# Altium schematic sheet Freq-Tim-Sheet1.SchDoc
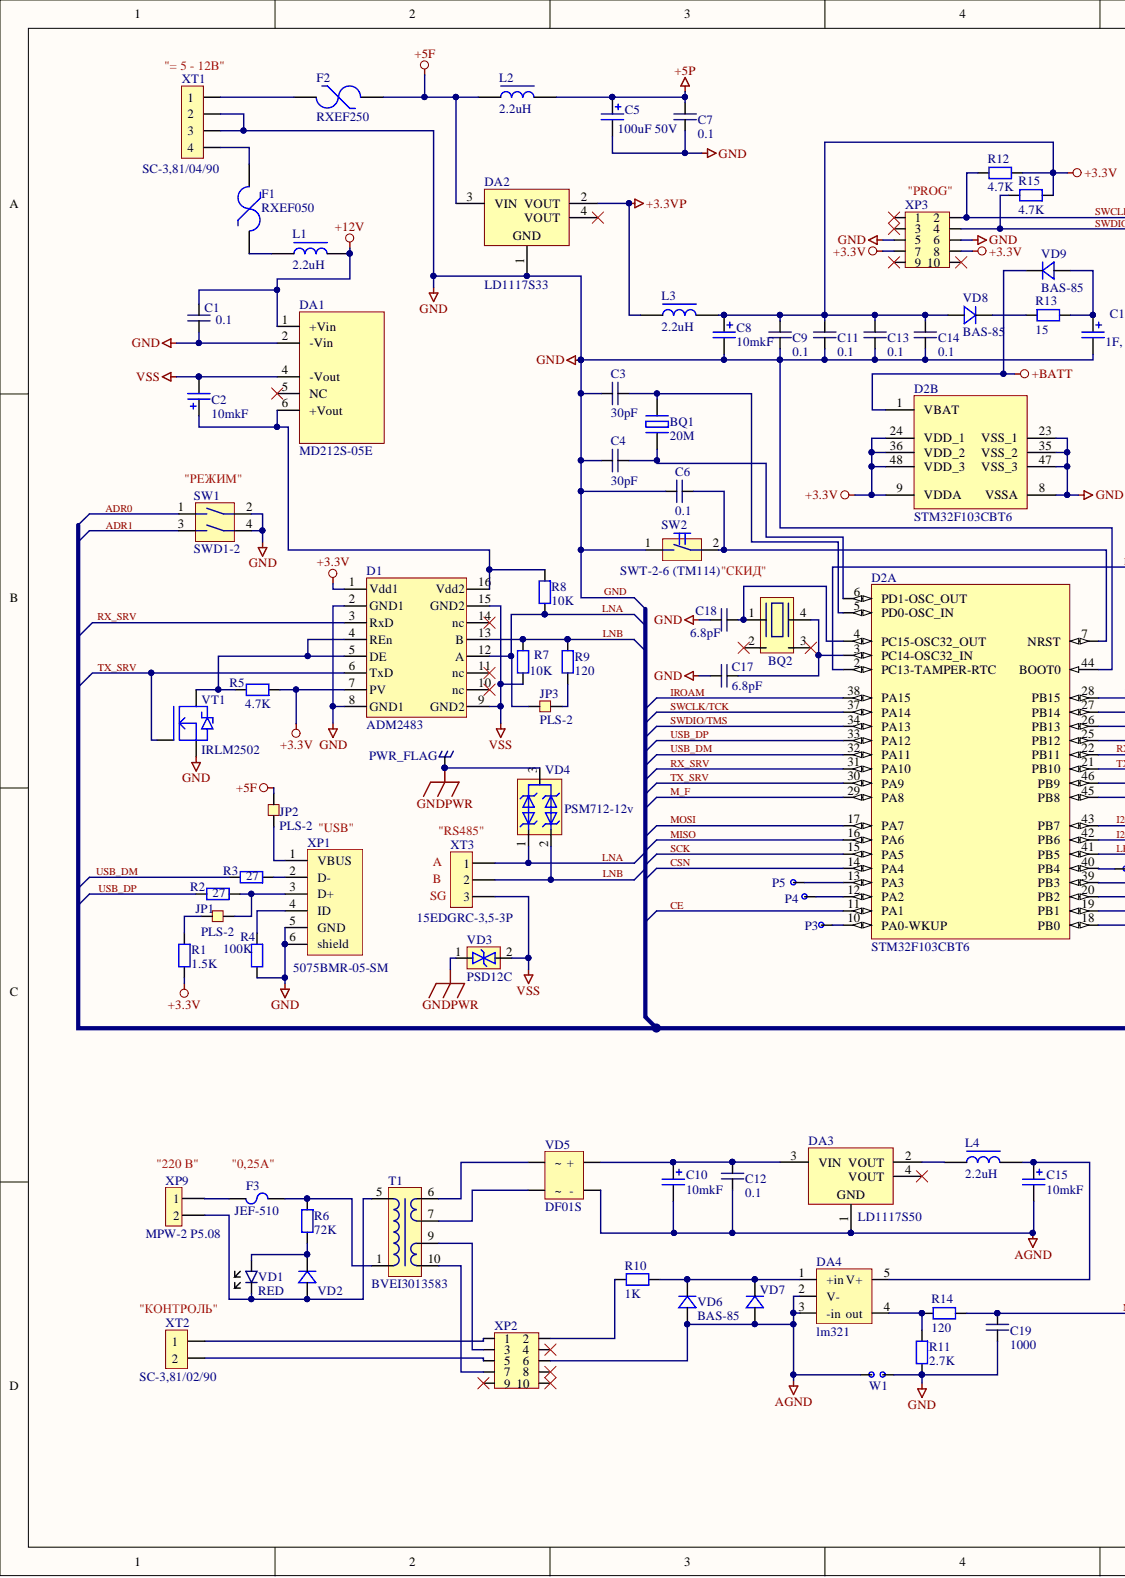
[diagram p0: Freq-Tim-Sheet1.SchDoc, left]
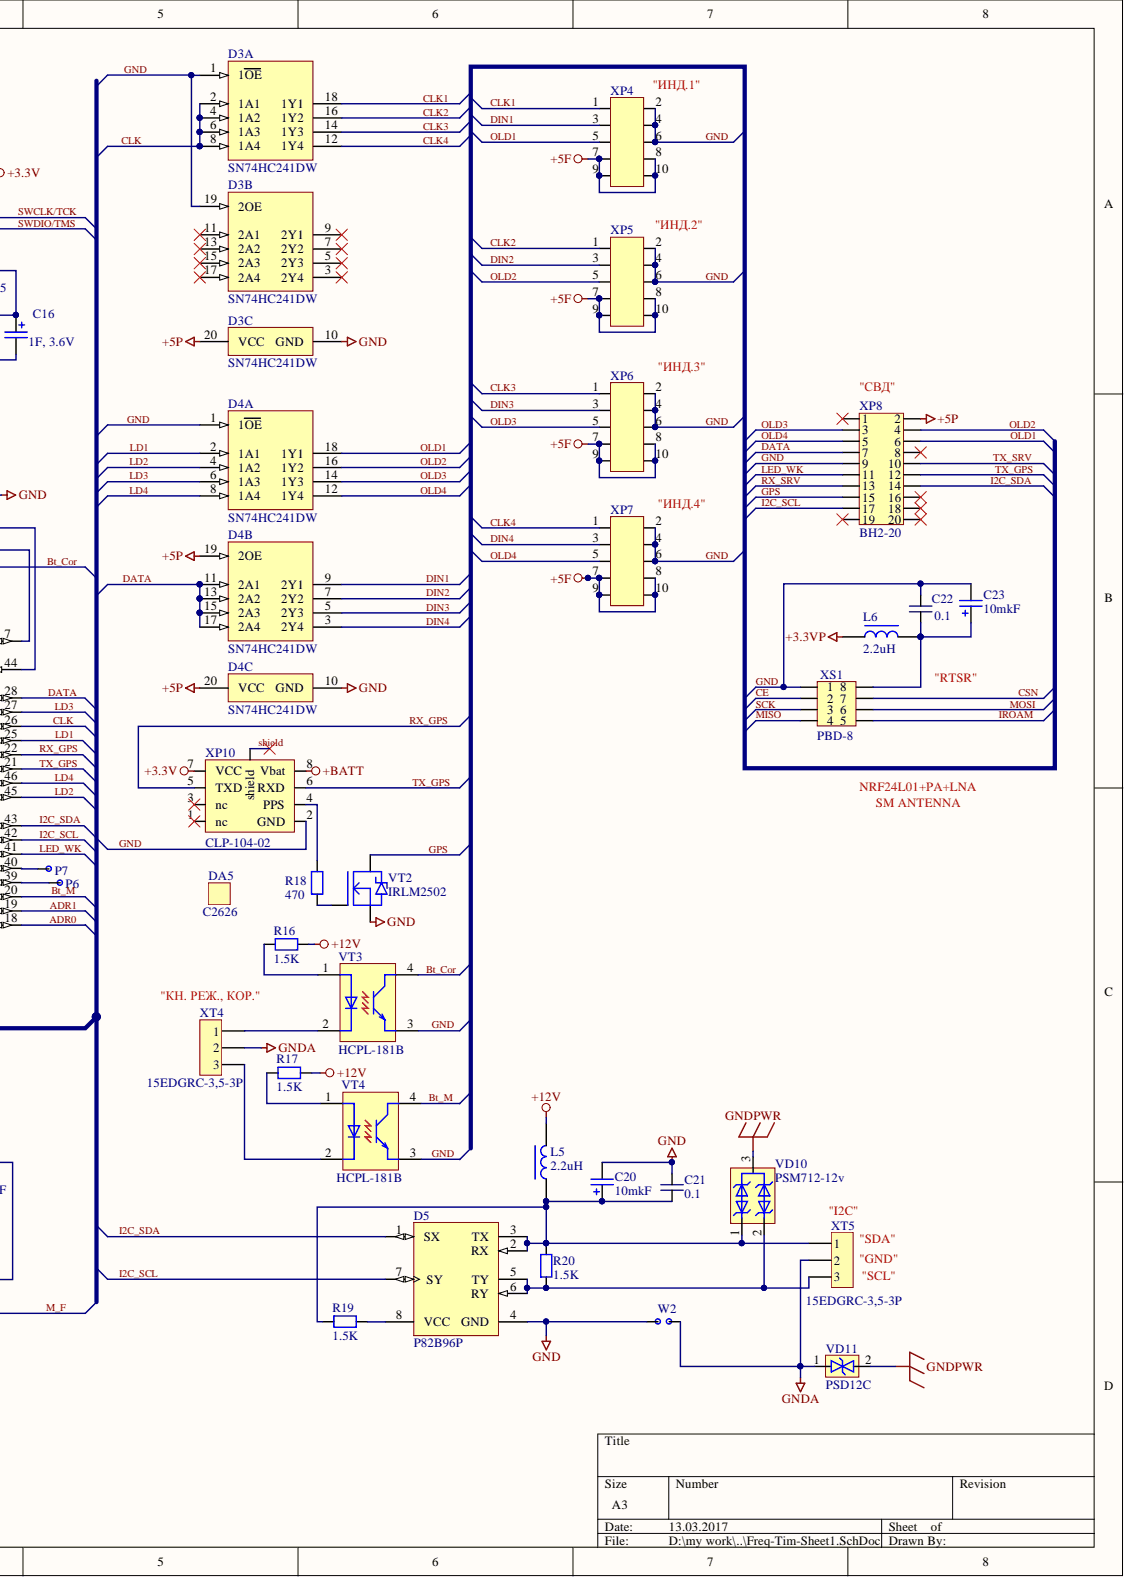
[diagram p1: Freq-Tim-Sheet1.SchDoc, right]

## Parts
BQ1  20M  [HC49US_th]
BQ2  32.768k  [MC306]  (value not drawn)
C1  0.1  [C1206]
C2  10mkF  [AL_CAP_6.5x5.5]
C3  30pF  [C1206]
C4  30pF  [C1206]
C5  100uF 50V  [AL_CAP_8x10]
C6  0.1  [C1206]
C7  0.1  [C1206]
C8  10mkF  [AL_CAP_6.5x5.5]
C9  0.1  [C1206]
C10  10mkF  [AL_CAP_6.5x5.5]
C11  0.1  [C1206]
C12  0.1  [C1206]
C13  0.1  [C1206]
C14  0.1  [C1206]
C15  10mkF  [AL_CAP_6.5x5.5]
C16  1F, 3.6V  [EEC-RG0V105HN]
C17  6.8pF  [C1206]
C18  6.8pF  [C1206]
C19  1000  [C1206]
C20  10mkF  [AL_CAP_6.5x5.5]
C21  0.1  [C1206]
C22  0.1  [C1206]
C23  10mkF  [AL_CAP_6.5x5.5]
D1  ADM2483  [SO16w]
D2  STM32F103CBT6  [STM-LQFP48_N]  (parts A,B)
D3  SN74HC241DW  [DW0020A_N]  (parts A,B,C)
D4  SN74HC241DW  [DW0020A_N]  (parts A,B,C)
D5  P82B96P  [P8]
DA1  MD212S-05E  [am2d-2405s]
DA2  LD1117S33  [SOT-223_N]
DA3  LD1117S50  [SOT-223_N]
DA4  lm321  [sot23_5]
DA5  C2626
F1  RXEF050  [RXEF]
F2  RXEF250  [RXEF250]
F3  JEF-510  [JEF-510]
JP1  PLS-2_  value PLS-2  [PLS-2]
JP2  PLS-2_  value PLS-2  [PLS-2]
JP3  PLS-2_  value PLS-2  [PLS-2]
L1  2.2uH  [P0770]
L2  2.2uH  [P0770]
L3  2.2uH  [P0770]
L4  2.2uH  [P0770]
L5  2.2uH  [P0770]
L6  2.2uH  [P0770]
P3  PIN_1  [PIN_1]  (value not drawn)
P4  PIN_1  [PIN_1]  (value not drawn)
P5  PIN_1  [PIN_1]  (value not drawn)
P6  PIN_1  [PIN_1]  (value not drawn)
P7  PIN_1  [PIN_1]  (value not drawn)
PWR_FLAG  Crimp tab (клемма ножевая угловая)  [earth_terminal_R]  (value not drawn)
R1  1.5K  [RESC3216N]
R2  27  [RESC3216N]
R3  27  [RESC3216N]
R4  100K  [RESC3216N]
R5  4.7K  [RESC3216N]
R6  72K  [RESC3216N]
R7  10K  [RESC3216N]
R8  10K  [RESC3216N]
R9  120  [RESC3216N]
R10  1K  [RESC3216N]
R11  2.7K  [RESC3216N]
R12  4.7K  [RESC3216N]
R13  15  [RESC3216N]
R14  120  [RESC3216N]
R15  4.7K  [RESC3216N]
R16  1.5K  [RESC3216N]
R17  1.5K  [RESC3216N]
R18  470  [RESC3216N]
R19  1.5K  [RESC3216N]
R20  1.5K  [RESC3216N]
SW1  SWD1-2  [SWD1-2]
SW2  SWT-2-6 (TM114)  [TM114]
T1  BVEI3013583  [BVEI301]
VD1  RED  [LED_TH_2.54_r]
VD2  S1A  [DO-214AC]  (value not drawn)
VD3  PSD12C  [SOD-323]
VD4  PSM712-12v  [SOT23N]
VD5  DF01S  [DF10S]
VD6  BAS-85  [SOD80]
VD7  S1A  [DO-214AC]  (value not drawn)
VD8  BAS-85  [SOD80]
VD9  BAS-85  [SOD80]
VD10  PSM712-12v  [SOT23N]
VD11  PSD12C  [SOD-323]
VT1  IRLM2502  [SOT23]
VT2  IRLM2502  [SOT23]
VT3  HCPL-181B  [HCPL-181]
VT4  HCPL-181B  [HCPL-181]
W1  PIN  [PIN]  (value not drawn)
W2  PIN  [PIN]  (value not drawn)
XP1  5075BMR-05-SM  [5075BMR-05-SM]
XP2  BH10  [BH10]  (value not drawn)
XP3  BH10  [BH10]  (value not drawn)
XP4  IDC10R  [BH-10R]  (value not drawn)
XP5  IDC10R  [BH-10R]  (value not drawn)
XP6  IDC10R  [BH-10R]  (value not drawn)
XP7  IDC10R  [BH-10R]  (value not drawn)
XP8  BH2-20  [BH2-20]
XP9  MPW-2 P5.08  [MPW-2]
XP10  CLP-104-02  [C2626_MODULE]
XS1  PBD-8  [PBD-8+NRF24L01-PA]
XT1  SC-3,81/04/90  [SC_3_81_90G_04]
XT2  SC-3,81/02/90  [SC_3_81_90G_02]
XT3  15EDGRC-3,5-3P  [15EDGRC-3,5-3P]
XT4  15EDGRC-3,5-3P  [15EDGRC-3,5-3P]
XT5  15EDGRC-3,5-3P  [15EDGRC-3,5-3P]

## Nets
+3.3V: C8.1 C9.2 C11.2 C13.2 C14.2 D1.1(Vdd1) D1.7(PV) D2.9(VDDA) D2.24(VDD_1) D2.36(VDD_2) D2.48(VDD_3) L3.2 R1.1 R5.2 R12.2 R15.2 VD8.A XP3.7 XP3.8 XP10.7(VCC)
+3.3VP: DA2.2(VOUT) L3.1 L6.1
+5F: DA2.3(VIN) F2.2 JP2.2 L2.1 XP4.7 XP4.8 XP4.9 XP4.10 XP5.7 XP5.8 XP5.9 XP5.10 XP6.7 XP6.8 XP6.9 XP6.10 XP7.7 XP7.8 XP7.9 XP7.10
+5P: C5.1 C7.2 D3.20(VCC) D4.19(2OE) D4.20(VCC) L2.2 XP8.2
+12V: C1.2 DA1.1(+Vin) L1.2 L5.2 R16.1 R17.1
+BATT: D2.1(VBAT) VD9.C XP10.8(Vbat)
AGND: C10.2 C12.1 C15.2 DA3.1 DA4.2(V-) DA4.3(-in) VD5.2(-) VD6.A VD7.2 W1.1 XP2.6
GNDA: VD11.1 W2.2 XT4.2 XT5.2
GNDPWR: PWR_FLAG.1 VD3.1 VD4.3 VD10.3 VD11.2
VSS: C2.2 D1.9(GND2) D1.15(GND2) DA1.4(-Vout) R7.1 VD3.2 XT3.3
ADR0: D2.18(PB0) SW1.1
ADR1: D2.19(PB1) SW1.3
BT_COR: D2.2(PC13-TAMPER-RTC) VT3.4
BT_M: D2.20(PB2) VT4.4
CE: D2.11(PA1) XS1.2
CLK: D2.26(PB13) D3.2(1A1) D3.4(1A2) D3.6(1A3) D3.8(1A4)
CLK1: D3.18(1Y1) XP4.1
CLK2: D3.16(1Y2) XP5.1
CLK3: D3.14(1Y3) XP6.1
CLK4: D3.12(1Y4) XP7.1
CSN: D2.14(PA4) XS1.7
DATA: D2.28(PB15) D4.11(2A1) D4.13(2A2) D4.15(2A3) D4.17(2A4) XP8.7
DIN1: D4.9(2Y1) XP4.3
DIN2: D4.7(2Y2) XP5.3
DIN3: D4.5(2Y3) XP6.3
DIN4: D4.3(2Y4) XP7.3
GND: C1.1 C3.1 C4.1 C5.2 C6.1 C7.1 C8.2 C9.1 C11.1 C13.1 C14.1 C16.2 C17.1 C18.1 C19.1 C20.2 C21.2 C22.2 C23.2 D1.2(GND1) D1.8(GND1) D2.8(VSSA) D2.23(VSS_1) D2.35(VSS_2) D2.44(BOOT0) D2.47(VSS_3) D3.1(1OE) D3.10(GND) D3.19(2OE) D4.1(1OE) D4.10(GND) D5.4(GND) DA1.2(-Vin) DA2.1 R4.1 R11.1 SW1.2 SW1.4 SW2.1 VT1.2 VT2.2 VT3.3 VT4.3 W1.2 W2.1 XP1.5(GND) XP1.6(shield) XP3.5 XP3.6 XP4.2 XP4.4 XP4.6 XP5.2 XP5.4 XP5.6 XP6.2 XP6.4 XP6.6 XP7.2 XP7.4 XP7.6 XP8.9 XP10.2(GND) XS1.1 XT1.2 XT1.3
GPS: VT2.3 XP8.15
I2C_SCL: D2.42(PB6) D5.7(SY) XP8.17
I2C_SDA: D2.43(PB7) D5.1(SX) XP8.14
IROAM: D2.38(PA15) XS1.5
LD1: D2.25(PB12) D4.2(1A1)
LD2: D2.45(PB8) D4.4(1A2)
LD3: D2.27(PB14) D4.6(1A3)
LD4: D2.46(PB9) D4.8(1A4)
LED_WK: D2.41(PB5) XP8.11
LNA: D1.12(A) JP3.1 R8.1 VD4.1 XT3.1
LNB: D1.13(B) R7.2 R9.2 VD4.2 XT3.2
M_F: C19.2 D2.29(PA8) R14.1
MISO: D2.16(PA6) XS1.4
MOSI: D2.17(PA7) XS1.6
OLD1: D4.18(1Y1) XP4.5 XP8.6
OLD2: D4.16(1Y2) XP5.5 XP8.4
OLD3: D4.14(1Y3) XP6.5 XP8.3
OLD4: D4.12(1Y4) XP7.5 XP8.5
RX_GPS: D2.22(PB11) XP10.5(TXD)
RX_SRV: D1.3(RxD) D2.31(PA10) XP8.13
SCK: D2.15(PA5) XS1.3
SHIELD: XP10.9(shield)
SWCLK/TCK: D2.37(PA14) R12.1 XP3.2
SWDIO/TMS: D2.34(PA13) R15.1 XP3.4
TX_GPS: D2.21(PB10) XP8.12 XP10.6(RXD)
TX_SRV: D1.6(TxD) D2.30(PA9) VT1.1 XP8.10
USB_DM: D2.32(PA11) R3.1
USB_DP: D2.33(PA12) R2.1
NetBQ1_1: BQ1.1 C4.2 D2.6(PD1-OSC_OUT)
NetBQ1_2: BQ1.2 C3.2 D2.5(PD0-OSC_IN)
NetBQ2_1: BQ2.1 C18.2 D2.4(PC15-OSC32_OUT)
NetBQ2_4: BQ2.4 C17.2 D2.3(PC14-OSC32_IN)
NetC2_1: C2.1 D1.16(Vdd2) DA1.6(+Vout) R8.2
NetC6_2: C6.2 D2.7(NRST) SW2.2
NetC10_1: C10.1 C12.2 DA3.3(VIN) VD5.1(+)
NetC15_1: C15.1 DA4.5(V+) L4.2
NetC16_1: C16.1 R13.1 VD9.A
NetC20_1: C20.1 C21.1 D5.2(RX) D5.3(TX) L5.1 R19.2 R20.1 VD10.1 XT5.1
NetC22_1: C22.1 C23.1 L6.2 XS1.8
NetD1_4: D1.4(REn) D1.5(DE) R5.1 VT1.3
NetD2_10: D2.10(PA0-WKUP) P3.1
NetD2_12: D2.12(PA2) P4.1
NetD2_13: D2.13(PA3) P5.1
NetD2_39: D2.39(PB3) P6.1
NetD2_40: D2.40(PB4) P7.1
NetD5_5: D5.5(TY) D5.6(RY) R20.2 VD10.2 XT5.3
NetD5_8: D5.8(VCC) R19.1
NetDA3_2: DA3.2(VOUT) L4.1
NetDA4_1: DA4.1(+in) R10.2 VD6.C VD7.1
NetDA4_4: DA4.4(out) R11.2 R14.2
NetF1_1: F1.1 L1.1
NetF1_2: F1.2 XT1.4
NetF2_1: F2.1 XT1.1
NetF3_1: F3.1 XP9.1
NetF3_2: F3.2 R6.2 T1.1
NetJP1_1: JP1.1 R1.2
NetJP1_2: JP1.2 R2.2 XP1.3(D+)
NetJP2_1: JP2.1 XP1.1(VBUS)
NetJP3_2: JP3.2 R9.1
NetR3_2: R3.2 XP1.2(D-)
NetR4_2: R4.2 XP1.4(ID)
NetR6_1: R6.1 VD1.1 VD2.1
NetR10_1: R10.1 XP2.2
NetR13_2: R13.2 VD8.C
NetR16_2: R16.2 VT3.1
NetR17_2: R17.2 VT4.1
NetR18_1: R18.1 XP10.4(PPS)
NetR18_2: R18.2 VT2.1
NetT1_5: T1.5 VD1.2 VD2.2 XP9.2
NetT1_6: T1.6 VD5.4(~)
NetT1_7: T1.7 VD5.3(~)
NetT1_9: T1.9 XP2.3
NetT1_10: T1.10 XP2.7
NetVT3_2: VT3.2 XT4.1
NetVT4_2: VT4.2 XT4.3
NetXP2_1: XP2.1 XT2.1
NetXP2_5: XP2.5 XT2.2
N00041:
N00042:

Not connected: BQ2 2 3; D1 10(nc) 11(nc) 14(nc); D3 3(2Y4) 5(2Y3) 7(2Y2) 9(2Y1) 11(2A1) 13(2A2) 15(2A3) 17(2A4); DA1 5(NC); DA2 4(VOUT); DA3 4(VOUT); XP2 4 8 9 10; XP3 1 3 9 10; XP8 1 8 16 18 19 20; XP10 1(nc) 3(nc)

## Library data of the parts
BQ1 = HC49US: Value: HC49US-20 MHz; Component Kind: Standard; Library Name: _Energosv.SchLib
BQ2 = MC306-G-06Q: Value: MC306-G-06Q-32.768; Component Kind: Standard; Library Name: _Energosv.SchLib
C1 C6 C7 C9 C11-C14 C21 C22 = C1206: Value: 1206 X7R 25V 100nF В± 5%; Component Kind: Standard; ValueShort: 0.1; Library Name: _Energosv.SchLib
C2 C8 C10 C15 C20 C23 = AL_CAP_6.5x5.5: Component Kind: Standard; Value: 10mkF; Library Name: _Energosv.SchLib
C3 C4 = C1206: Value: 1206 X7R 25V 30 pF ± 5%; Component Kind: Standard; ValueShort: 30pF; Library Name: _Energosv.SchLib
C5 = AL_CAP_8x10: Component Kind: Standard; Value: 100uF 50V; Library Name: _Energosv.SchLib
C16 = EEC-RG0V105HN: Component Kind: Standard; Value: EEC-RG0V105HN; ValueShort: 1F, 3.6V; Library Name: _Energosv.SchLib
C17 C18 = C1206: Value: 1206 X7R 25V 6,8 pF ± 5%; Component Kind: Standard; ValueShort: 6.8pF; Library Name: _Energosv.SchLib
C19 = C1206: Value: 1206 X7R 25V 1nF± 5%; Component Kind: Standard; ValueShort: 1000; Library Name: _Energosv.SchLib
D1 = ADM2483: Value: ADM2483BRW-SO16w; Component Kind: Standard; Library Name: _Energosv.SchLib
D2 = STM32F103CBT6: ARM Cortex-M3 32-bit MCU, 128 KB Flash, 20 KB Internal RAM, 37 I/Os, 48-pin LQFP, -40 to 85 degC, Tray; A/D Converter: 2x12-bit (10 Channel); FLASH Size (Prog) (kB): 128; I/Os (High Current): 37; Internal RAM Size (kB): 20; Operating Frequency(F) (Processor speed) (MHz): 72; Supply Voltage(Vcc) min (V): 2; Component Kind: Standard; ComponentLink1Description: Manufacturer URL; ComponentLink1URL: http://www.st.com/web/en/home.html; ComponentLink2Description: Datasheet; ComponentLink2URL: http://www.st.com/st-web-ui/static/active/en/resource/technical/document/datasheet/CD00161566.pdf; ComponentLink3Description: Package Specification; ComponentLink3URL: http://www.st.com/st-web-ui/static/active/en/resource/technical/document/datasheet/DM00058181.pdf; Core: ARM Cortex-M3; DatasheetVersion: Rev. 16; Mounting Technology: Surface Mount; PackageDescription: 48-Pin Low Profile Quad Flat Package, 7 x 7 mm Body, 0.5 mm Pitch; PackageReference: LQFP48; PackageVersion: Rev. 6, 06/2013; Packing: Tray; PartNumber: STM32F103CBT6; Supplier 1: Digi-Key; Supplier 2: Farnell; Supplier 3: Newark; Supplier 4: Newark; Supplier 5: Mouser; Supplier Part Number 1: 497-6288-ND; Supplier Part Number 2: 1606327; Supplier Part Number 3: 11N8296; Supplier Part Number 4: 57P1214; Supplier Part Number 5: 511-STM32F103CBT6; Library Name: _Energosv.SchLib
D3 D4 = SN74HC241DW: Octal Buffers And Line Drivers With 3-State Outputs, DW0020A, TUBE; Manufacturer: Texas Instruments; Bits(#): 8; F @ Nom Voltage(Max)(Mhz): 28; ICC @ Nom Voltage(Max)(mA): 0.08; Input Type: CMOS; Rating: Catalog; tpd @ Nom Voltage(Max)(ns): 25; VCC(Max)(V): 6; VCC(Min)(V): 2; Code_JEDEC: MS-013; Component Kind: Standard; ComponentLink1Description: Manufacturer URL; ComponentLink1URL: http://www.ti.com/; ComponentLink2Description: Datasheet; ComponentLink2URL: http://www.ti.com/lit/ds/symlink/sn74hc241.pdf; ComponentLink3Description: Package Specification; ComponentLink3URL: http://www.ti.com/lit/ml/mpds173a/mpds173a.pdf; DatasheetVersion: SCLS300C; Generic Part Number: SN74HC241; Operating Temperature Range(C): -40 to 85; Output Type: CMOS; PackageReference: DW0020A; PartNumber: SN74HC241DW; Voltage(Nom)(V): 6; Library Name: _Energosv.SchLib
D5 = P82B96P: Dual Bidirectional Bus Buffer, 2 Channel Width, 2.0 to 15.0 V, -40 to 85 degC, 8-pin PDIP (P), Pb-Free (RoHS); Manufacturer: Texas Instruments; 5V Tolerant I/O: Yes; Channel Width: 2; Eco-Plan: Green (RoHS & no Sb/Br); Master Side I2C Bus Capacitance Supported(pF): 400; SubFamily: I2C Hub, Buffer, Repeater; VCC(Max)(V): 15; Code_JEDEC: MS-001-BA; Component Kind: Standard; ComponentLink1Description: Datasheet; ComponentLink1URL: http://www.ti.com/general/docs/lit/getliterature.tsp?genericPartNumber=P82B96&fileType=pdf; ComponentLink2Description: Package Specification; ComponentLink2URL: http://www.ti.com/litv/pdf/mpdi001b; DatasheetVersion: SCPS144B; Max Frequency(kHz): 400; Mounting Technology: Through-hole; No. of Outputs: 2; Open Drain I/O Type: Yes; PackageDescription: 8-Pin Plastic Dual-In-Line Package, Row Spacing 7.62 mm, Pitch 2.54 mm; PackageReference: P8; PackageVersion: revE, Apr-2010; PartNumber: P82B96P; Slave Side I2C Bus Capacitance Supported(pF): 400; Supplier 1: Digi-Key; Supplier 2: Farnell; Supplier 3: Newark; Supplier 4: Mouser; Supplier Part Number 1: 296-20943-5-ND; Supplier Part Number 2: 1576673; Supplier Part Number 3: 88K6386; Supplier Part Number 4: 595-P82B96P; VCC(Min)(V): 2; Vcc range(V): 2.0 to 15.0; Library Name: _Energosv.SchLib
DA2 = LD1117S33: Fixed Low Drop Positive Voltage Regulator, 3.3V, 4-Pin SOT-223, Tape and Reel; PartNumber: LD1117S33TR; Component Kind: Standard; ComponentLink1Description: Manufacturer URL; ComponentLink1URL: http://www.st.com; ComponentLink2Description: Datasheet; ComponentLink2URL: http://www.st.com/internet/com/TECHNICAL_RESOURCES/TECHNICAL_LITERATURE/DATASHEET/CD00000544.pdf; DatasheetVersion: Nov-2011; PackageDescription: 4-Pin SOT-223 Package, 6.5 x 3.5 mm Body, 2.3 mm Pitch, 7 mm Lead Span; PackageReference: SOT-223; PackageVersion: 2010; Library Name: _Energosv.SchLib
DA3 = LD1117S50: Fixed Low Drop Positive Voltage Regulator, 5V, 4-Pin SOT-223, Tape and Reel; PartNumber: LD1117S50TR; Component Kind: Standard; ComponentLink1Description: Manufacturer URL; ComponentLink1URL: http://www.st.com; ComponentLink2Description: Datasheet; ComponentLink2URL: http://www.st.com/internet/com/TECHNICAL_RESOURCES/TECHNICAL_LITERATURE/DATASHEET/CD00000544.pdf; DatasheetVersion: Nov-2011; PackageDescription: 4-Pin SOT-223 Package, 6.5 x 3.5 mm Body, 2.3 mm Pitch, 7 mm Lead Span; PackageReference: SOT-223; PackageVersion: 2010; Library Name: _Energosv.SchLib
DA5 = DummyComponent: Component Kind: Standard; Value: C2626; Library Name: _Energosv.SchLib
L1-L6 = P0770.xxx: Value: P0770.222-SMD; Component Kind: Standard; Library Name: _Energosv.SchLib
P3-P7 = PIN_1: Value: PINx; Component Kind: Standard; Library Name: _Energosv.SchLib
PWR_FLAG = EARTH_pin_R: Component Kind: Standard; Value: Crimp tab (клемма ножевая угловая); Library Name: _Energosv.SchLib
R1 R16 R17 R19 R20 = R1206: Value: 1206 RC01 1,5 kOm ± 5%; Component Kind: Standard; ValueShort: 1.5K; Library Name: _Energosv.SchLib
R2 R3 = R1206: Value: 1206 RC01 27 Om ± 5%; Component Kind: Standard; ValueShort: 27; Library Name: _Energosv.SchLib
R4 = R1206: Value: 1206 RC01 100 кОm ± 5%; Component Kind: Standard; ValueShort: 100K; Library Name: _Energosv.SchLib
R5 R12 R15 = R1206: Value: 1206 RC01 4,7 кОm ±5 %; Component Kind: Standard; ValueShort: 4.7K; Library Name: _Energosv.SchLib
R6 = R1206: Value: 1206 RC01 72 kOm ± 5%; Component Kind: Standard; ValueShort: 72K; Library Name: _Energosv.SchLib
R7 R8 = R1206: Value: 1206 RC01 10 кОm ± 5%; Component Kind: Standard; ValueShort: 10K; Library Name: _Energosv.SchLib
R9 R14 = R1206: Value: 1206 RC01 120 Оm ± 5%; Component Kind: Standard; ValueShort: 120; Library Name: _Energosv.SchLib
R10 = R1206: Value: 1206 RC01 1,0 кОm ± 5%; Component Kind: Standard; ValueShort: 1K; Library Name: _Energosv.SchLib
R11 = R1206: Value: 1206 RC01 2,7 kOm ± 5%; Component Kind: Standard; ValueShort: 2.7K; Library Name: _Energosv.SchLib
R13 = R1206: Value: 1206 RC01 15 Om ± 5%; Component Kind: Standard; ValueShort: 15; Library Name: _Energosv.SchLib
R18 = R1206: Value: 1206 RC01 470 Om ± 5%; Component Kind: Standard; ValueShort: 470; Library Name: _Energosv.SchLib
SW2 = SWT-2-6: Component Kind: Standard; Library Name: _Energosv.SchLib
VD1 = LED_th_r: Value: L-934SURC; Component Kind: Standard; Library Name: _Energosv.SchLib
VD3 VD11 = PSD12C: TVS; Component Kind: Standard; Value: PSD12C; Library Name: _Energosv.SchLib
VD4 VD10 = PSM712-12v: TVS array; Component Kind: Standard; Value: PSM712-12v; Library Name: _Energosv.SchLib
VD5 = DF01S: Bridge rectifier; Component Kind: Standard; Value: DF01S; Library Name: _Energosv.SchLib
VT3 VT4 = HCPL-181B: Optocoupler; Component Kind: Standard; Value: HCPL-181B; Library Name: _Energosv.SchLib
W1 W2 = PIN: Value: PINx; Component Kind: Standard; Library Name: _Energosv.SchLib
XP1 = 5075BMR-05-SM: Mini USB male; Component Kind: Standard; Value: 5075BMR-05-SM; Library Name: _Energosv.SchLib
XP4-XP7 = BH-10R: Component Kind: Standard; Value: IDC10R; Library Name: _Energosv.SchLib
XP9 = MPW-2: Component Kind: Standard; Value: MPW-2 P5.08; Library Name: _Energosv.SchLib
XP10 = C2626_CLP-104-02: Component Kind: Standard; Value: CLP-104-02; Library Name: _Energosv.SchLib
XT1 = SC-3,81/04/90G: Component Kind: Standard; Value: SC-3,81/04/90; Library Name: _Energosv.SchLib
XT2 = SC-3,81/02/90G: Component Kind: Standard; Value: SC-3,81/02/90; Library Name: _Energosv.SchLib
DA1 = MD212S-05E: Component Kind: Standard; Value: MD212S-05E; Library Name: _Energosv.SchLib
DA4 = lm321: Component Kind: Standard; Value: lm321; Library Name: _Energosv.SchLib
F1 = RXEF050: Component Kind: Standard; Value: RXEF050; Library Name: _Energosv.SchLib
F2 = RXEF250: Component Kind: Standard; Value: RXEF250; Library Name: _Energosv.SchLib
F3 = JEF-510: Component Kind: Standard; Value: JEF-510; Library Name: _Energosv.SchLib
JP1-JP3 = PLS-2_: Component Kind: Standard; Library Name: _Energosv.SchLib
SW1 = SWD1-2: Component Kind: Standard; Library Name: _Energosv.SchLib
T1 = BVEI3013583: Component Kind: Standard; Library Name: _Energosv.SchLib
VD2 VD7 = S1A: Component Kind: Standard; Value: S1A; Library Name: _Energosv.SchLib
VD6 VD8 VD9 = BAS-85: Component Kind: Standard; Value: BAS-85; Library Name: _Energosv.SchLib
VT1 VT2 = IRLM2502: Component Kind: Standard; Value: IRLM2502; Library Name: _Energosv.SchLib
XP2 XP3 = BH10: Component Kind: Standard; Value: BH10; Library Name: _Energosv.SchLib
XP8 = BH2-20: Component Kind: Standard; Value: BH2-20; Library Name: _Energosv.SchLib
XS1 = PBD-8: Component Kind: Standard; Value: PBD-8; Library Name: _Energosv.SchLib
XT3-XT5 = 15EDGRC-3,5-3P: Component Kind: Standard; Value: 15EDGRC-3,5-3P; Library Name: _Energosv.SchLib
